# Altium schematic sheet FPGA-PWR.SchDoc(FPGA-PWR)
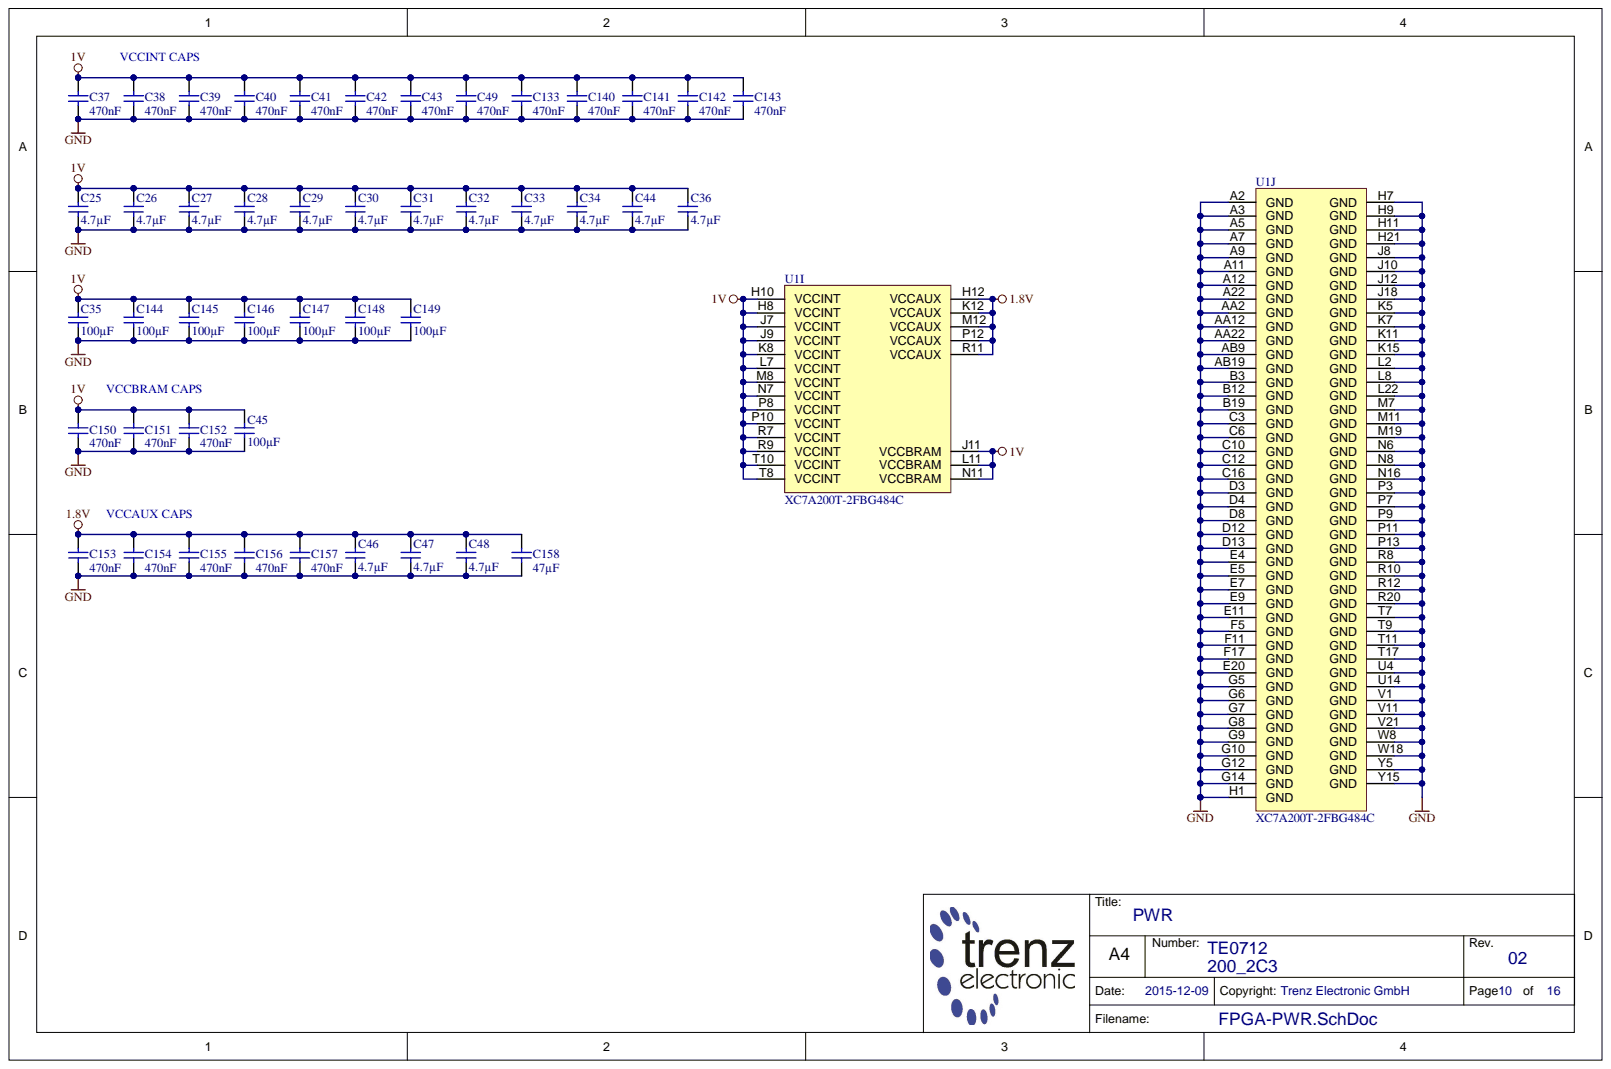
[diagram p0: FPGA-PWR.SchDoc]

## Parts
C25  4.7µF  [CAPC1005X60N]
C26  4.7µF  [CAPC1005X60N]
C27  4.7µF  [CAPC1005X60N]
C28  4.7µF  [CAPC1005X60N]
C29  4.7µF  [CAPC1005X60N]
C30  4.7µF  [CAPC1005X60N]
C31  4.7µF  [CAPC1005X60N]
C32  4.7µF  [CAPC1005X60N]
C33  4.7µF  [CAPC1005X60N]
C34  4.7µF  [CAPC1005X60N]
C35  100µF  [CAPC3216X180N]
C36  4.7µF  [CAPC1005X60N]
C37  470nF  [CAPC0603X33N]
C38  470nF  [CAPC0603X33N]
C39  470nF  [CAPC0603X33N]
C40  470nF  [CAPC0603X33N]
C41  470nF  [CAPC0603X33N]
C42  470nF  [CAPC0603X33N]
C43  470nF  [CAPC0603X33N]
C44  4.7µF  [CAPC1005X60N]
C45  100µF  [CAPC3216X180N]
C46  4.7µF  [CAPC1005X60N]
C47  4.7µF  [CAPC1005X60N]
C48  4.7µF  [CAPC1005X60N]
C49  470nF  [CAPC0603X33N]
C133  470nF  [CAPC0603X33N]
C140  470nF  [CAPC0603X33N]
C141  470nF  [CAPC0603X33N]
C142  470nF  [CAPC0603X33N]
C143  470nF  [CAPC0603X33N]
C144  100µF  [CAPC3216X180N]
C145  100µF  [CAPC3216X180N]
C146  100µF  [CAPC3216X180N]
C147  100µF  [CAPC3216X180N]
C148  100µF  [CAPC3216X180N]
C149  100µF  [CAPC3216X180N]
C150  470nF  [CAPC0603X33N]
C151  470nF  [CAPC0603X33N]
C152  470nF  [CAPC0603X33N]
C153  470nF  [CAPC0603X33N]
C154  470nF  [CAPC0603X33N]
C155  470nF  [CAPC0603X33N]
C156  470nF  [CAPC0603X33N]
C157  470nF  [CAPC0603X33N]
C158  47µF  [CAPC2012X135N]
U1  XC7A200T-2FBG484C  [BGA484C100P22X22_2300X2300X254N]  (parts I,J)
U1  XC7A200T-1FBG484I  [BGA484C100P22X22_2300X2300X254N]  (value not drawn)  (part I)
U1  XC7A200T-1FBG484I  [BGA484C100P22X22_2300X2300X254N]  (value not drawn)  (part J)
U1  XC7A100T-1FGG484I  [BGA484C100P22X22_2300X2300X254N]  (value not drawn)  (part I)
U1  XC7A100T-1FGG484I  [BGA484C100P22X22_2300X2300X254N]  (value not drawn)  (part J)

## Nets
1.8V: C46.1 C47.1 C48.1 C153.1 C154.1 C155.1 C156.1 C157.1 C158.1 U1.H12 U1.K12 U1.M12 U1.P12 U1.R11
1V: C25.1 C26.1 C27.1 C28.1 C29.1 C30.1 C31.1 C32.1 C33.1 C34.1 C35.2 C36.1 C37.1 C38.1 C39.1 C40.1 C41.1 C42.1 C43.1 C44.1 C45.1 C49.1 C133.1 C140.1 C141.1 C142.1 C143.1 C144.2 C145.2 C146.2 C147.2 C148.2 C149.2 C150.1 C151.1 C152.1 U1.H8 U1.H10 U1.J7 U1.J9 U1.J11 U1.K8 U1.L7 U1.L11 U1.M8 U1.N7 U1.N11 U1.P8 U1.P10 U1.R7 U1.R9 U1.T8 U1.T10
GND: C25.2 C26.2 C27.2 C28.2 C29.2 C30.2 C31.2 C32.2 C33.2 C34.2 C35.1 C36.2 C37.2 C38.2 C39.2 C40.2 C41.2 C42.2 C43.2 C44.2 C45.2 C46.2 C47.2 C48.2 C49.2 C133.2 C140.2 C141.2 C142.2 C143.2 C144.1 C145.1 C146.1 C147.1 C148.1 C149.1 C150.2 C151.2 C152.2 C153.2 C154.2 C155.2 C156.2 C157.2 C158.2 U1.A2 U1.A3 U1.A5 U1.A7 U1.A9 U1.A11 U1.A12 U1.A22 U1.AA2 U1.AA12 U1.AA22 U1.AB9 U1.AB19 U1.B3 U1.B12 U1.B19 U1.C3 U1.C6 U1.C10 U1.C12 U1.C16 U1.D3 U1.D4 U1.D8 U1.D12 U1.D13 U1.E4 U1.E5 U1.E7 U1.E9 U1.E11 U1.E20 U1.F5 U1.F11 U1.F17 U1.G5 U1.G6 U1.G7 U1.G8 U1.G9 U1.G10 U1.G12 U1.G14 U1.H1 U1.H7 U1.H9 U1.H11 U1.H21 U1.J8 U1.J10 U1.J12 U1.J18 U1.K5 U1.K7 U1.K11 U1.K15 U1.L2 U1.L8 U1.L22 U1.M7 U1.M11 U1.M19 U1.N6 U1.N8 U1.N16 U1.P3 U1.P7 U1.P9 U1.P11 U1.P13 U1.R8 U1.R10 U1.R12 U1.R20 U1.T7 U1.T9 U1.T11 U1.T17 U1.U4 U1.U14 U1.V1 U1.V11 U1.V21 U1.W8 U1.W18 U1.Y5 U1.Y15

## Library data of the parts
C25-C34 C36 C44 C46-C48 = 24719: KON 4.7µF 6.3V X5R SMD 20%; Database Table Name: C_1005_0402; Mixed: SMT; Preferred: Yes; Value: 4.7u; Voltage: 6.3; AN: 24719; Case: 1005 (0402); Component Kind: Standard; Library Name: TE.DbLib; Technology: X5R; Tolerance: 20%
C35 C45 C144-C149 = 23116: KON 100µF 6,3V X5R SMD 20%; Database Table Name: C_3216_1206; Mixed: SMT; Preferred: Yes; Value: 100u; Voltage: 6.3V; AN: 23116; Case: 3216 (1206); Component Kind: Standard; Library Name: TE.DbLib; Technology: X5R; Tolerance: 20%
C37-C43 C49 C133 C140-C143 C150-C157 = 24921: KON 470nF X5R 6.3V SMD 20%; Database Table Name: C_0603_0201; Mixed: SMT; Preferred: Yes; Value: 470n; AN: 24921; Case: 0603 (0201); Component Kind: Standard; Library Name: TE.DbLib; Technology: X5R; Tolerance: 20%; Voltage: 6.3V
C158 = 24718: KON 47µF 6,3V  X5R SMD 20%; Database Table Name: C_2012_0805; Mixed: SMT; Preferred: Yes; Value: 47u; Voltage: 6.3V; AN: 24718; Case: 2012 (0805); Component Kind: Standard; Library Name: TE.DbLib; Technology: X5R; Tolerance: 20%
U1 = 25321: Database Table Name: PROG_LOGIC; Mixed: SMT; Trenz-Stock: Yes; AN: 25321; Case: BGA484; Component Kind: Standard; Description: XC7A200T-2FBG484C; H-ART: XC7A200T-2FBG484C; Library Name: TE.DbLib; HelpURL: B:\AD\adlib\datasheet\XC7
U1 U1 = 26528: Database Table Name: PROG_LOGIC; Mixed: SMT; Trenz-Stock: Yes; AN: 26528; Case: BGA484; Component Kind: Standard; Description: XC7A200T-1FBG484I; H-ART: XC7A200T-1FBG484I; Library Name: TE.DbLib; HelpURL: B:\AD\adlib\datasheet\XC7
U1 U1 = 26530: Database Table Name: PROG_LOGIC; Mixed: SMT; Trenz-Stock: Yes; AN: 26530; Case: BGA484; Component Kind: Standard; Description: XC7A100T-1FGG484I; H-ART: XC7A100T-1FGG484I; Library Name: TE.DbLib; HelpURL: B:\AD\adlib\datasheet\XC7
